# Altium schematic sheet PowerSupply.SchDoc(PowerSupply)
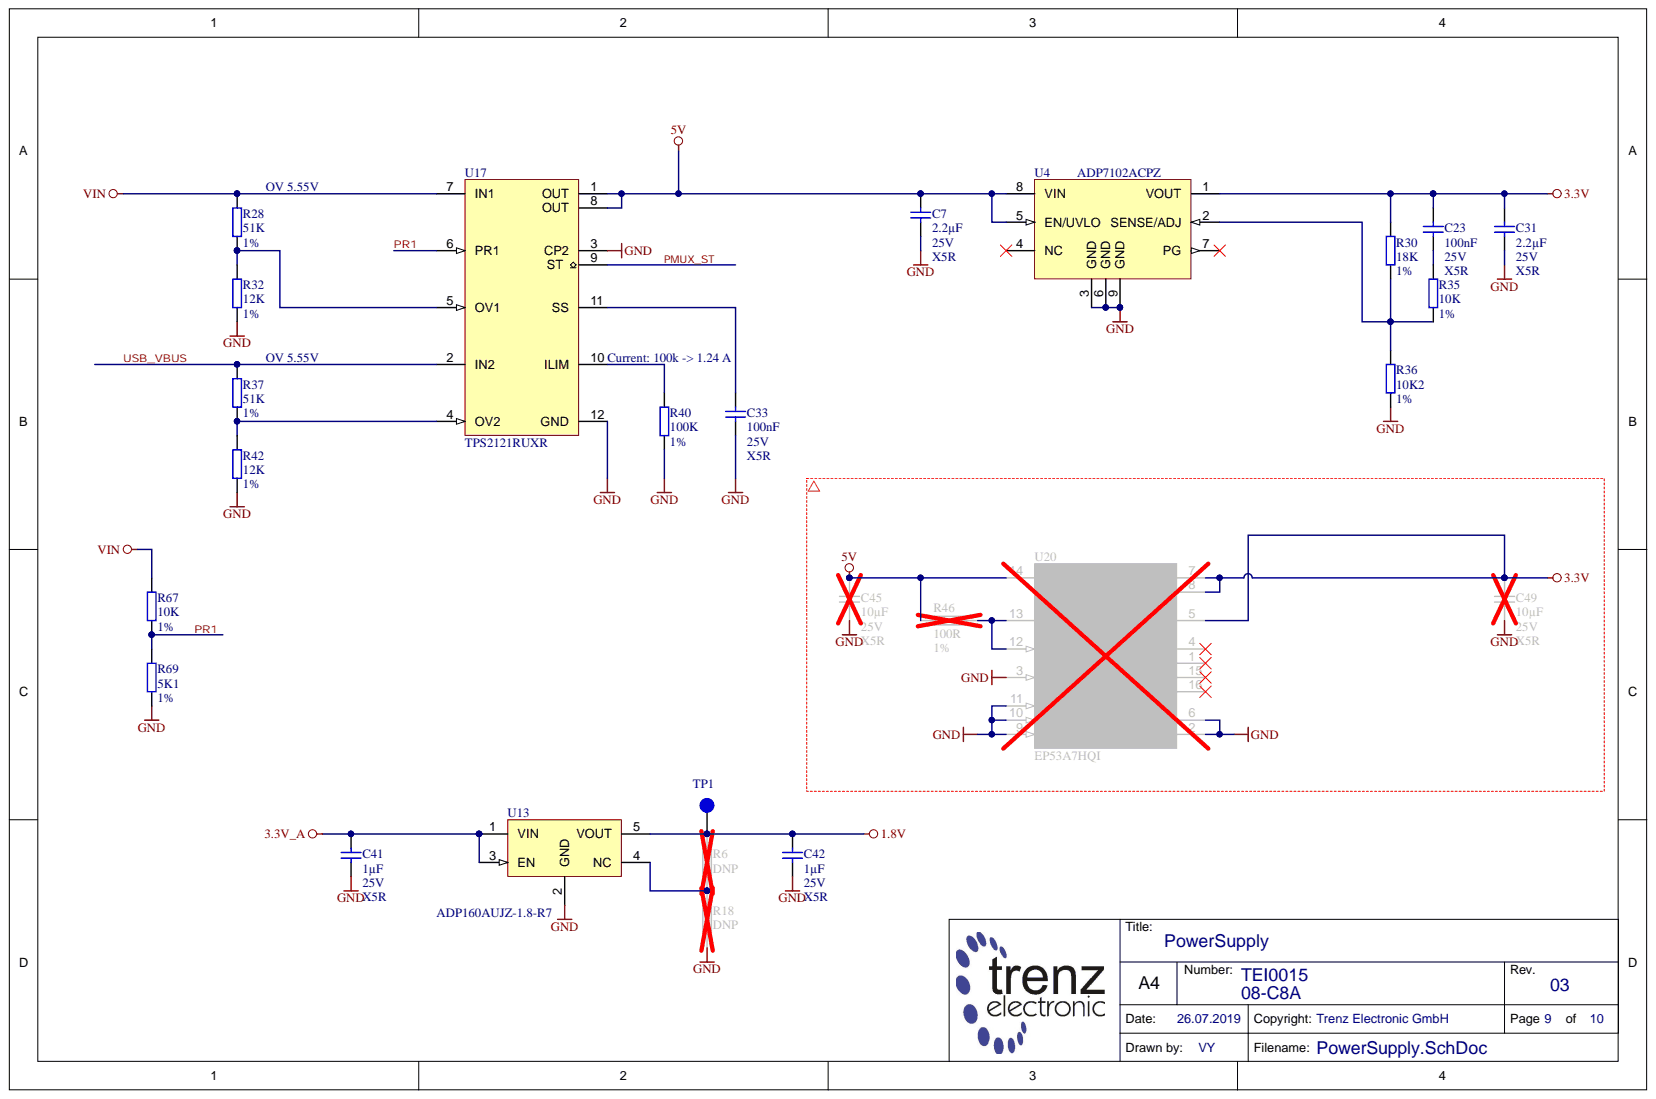
[diagram p0: PowerSupply.SchDoc]

## Parts
C7  2.2µF  Technology: X5R  Voltage: 25V  [CAPC1608X90N]
C23  100nF  Voltage: 25V  [CAPC1005X55N]
C31  2.2µF  Technology: X5R  Voltage: 25V  [CAPC1608X90N]
C33  100nF  Technology: X5R  Voltage: 25V  [CAPC1005X55N]
C41  1µF  Technology: X5R  Voltage: 25V  [CAPC1608X90N]
C42  1µF  Technology: X5R  Voltage: 25V  [CAPC1608X90N]
C45  10µF  Technology: X5R  Voltage: 25V  [CAPC2012X135N]
C49  10µF  Technology: X5R  Voltage: 25V  [CAPC2012X135N]
R6  DNP  [RESC1005X40N]  (no BOM)
R18  DNP  [RESC1005X40N]  (no BOM)
R28  51K  Tolerance: 1%  [RESC1005X40N]
R30  18K  Tolerance: 1%  [RESC1005X40N]
R32  12K  Tolerance: 1%  [RESC1005X40N]
R35  10K  Tolerance: 1%  [RESC1005X40N]
R36  10K2  Tolerance: 1%  [RESC1005X40N]
R37  51K  Tolerance: 1%  [RESC1005X40N]
R40  100K  Tolerance: 1%  [RESC1005X40N]
R42  12K  Tolerance: 1%  [RESC1005X40N]
R46  100R  Tolerance: 1%  [RESC1005X40N]
R67  10K  Tolerance: 1%  [RESC1005X40N]
R69  5K1  Tolerance: 1%  [RESC1005X40N]
TP1  Testpoint 0.8mm  [TP_0.8mm]  (value not drawn)
U4  ADP7102ACPZ  [SON50P300X300X80-9N]
U13  ADP160AUJZ-1.8-R7  [SOT95P280X100-5N]
U17  TPS2121RUXR  [QFNP50P200X250X100-12N]
U20  EP53A7HQI  [EP53F8QI]

## Nets
1.8V: C42.1 R6.2 TP1.1 U13.5(VOUT)
3.3V: C23.1 C31.1 C49.1 R30.1 U4.1(VOUT) U20.5(VSENSE) U20.7(VOUT) U20.8(VOUT)
3.3V_A: C41.1 U13.1(VIN) U13.3(EN)
5V: C7.1 C45.1 R46.1 U4.5(EN/UVLO) U4.8(VIN) U17.1(OUT) U17.8(OUT) U20.14(PVIN)
GND: C7.2 C31.2 C33.2 C41.2 C42.2 C45.2 C49.2 R18.1 R32.2 R36.2 R40.2 R42.2 R69.2 U4.3(GND) U4.6(GND) U4.9(GND) U13.2(GND) U17.3(CP2) U17.12(GND) U20.2(PGND) U20.3(LLM) U20.6(AGND) U20.9(VS2) U20.10(VS1) U20.11(VS0)
VIN: R28.1 R67.1 U17.7(IN1)
PMUX_ST: U17.9(ST)
PR1: R67.2 R69.1 U17.6(PR1)
USB_VBUS: R37.1 U17.2(IN2)
NetC23_2: C23.2 R35.1
NetC33_1: C33.1 U17.11(SS)
NetR6_1: R6.1 R18.2 U13.4(NC)
NetR28_2: R28.2 R32.1 U17.5(OV1)
NetR30_2: R30.2 R35.2 R36.1 U4.2(SENSE/ADJ)
NetR37_2: R37.2 R42.1 U17.4(OV2)
NetR40_1: R40.1 U17.10(ILIM)
NetR46_2: R46.2 U20.12(ENABLE) U20.13(AVIN)

Not connected: U4 4(NC) 7(PG); U20 1(NC(SW)) 4(NC) 15(NC(SW)) 16(NC(SW))

## Library data of the parts
C7 C31 = 27910: KON 2.2uF 25V X5R ±10% SMD BF 1608 (0603); Database Table Name: C_1608_0603; Mixed: SMT; Temperature-Range: -55/+85; Value: 2.2u; AN: 27910; Case: 1608 (0603); Component Kind: Standard; Library Name: TE.DbLib; Tolerance: ±10%
C23 C33 = 22472: KON 100nF 25V X5R ±10% SMD BF 1005 (0402); Database Table Name: C_1005_0402; Mixed: SMT; Preferred: Yes; Temperature-Range: -55/+85; Value: 100n; AN: 22472; Case: 1005 (0402); Component Kind: Standard; Library Name: TE.DbLib
  C23: Technology: X5R
C41 C42 = 26837: KON 1µF 25V X5R ±10% SMD BF 1608 (0603); Database Table Name: C_1608_0603; Mixed: SMT; Preferred: Yes; Temperature-Range: -55/+85; Value: 1u; AN: 26837; Case: 1608 (0603); Component Kind: Standard; Library Name: TE.DbLib; Tolerance: 10%
C45 C49 = 25567: KON 10µF 25V X5R 10% SMD BF 2012 (0805); Database Table Name: C_2012_0805; Mixed: SMT; Temperature-Range: -55/+85; Value: 10u; AN: 25567; Case: 2012 (0805); Component Kind: Standard; Library Name: TE.DbLib; Tolerance: 10%
R6 R18 = 29416: WID SMD BF 1005 (0402) DNP; Database Table Name: R_1005_0402; AN: 29416; Case: 1005 (0402); Library Name: TE.DbLib; Value: DNP
R28 R37 = 24466: WID SMD 51K 1% 0,063W; Database Table Name: R_1005_0402; Mixed: SMT; AN: 24466; Case: 1005 (0402); Component Kind: Standard; Library Name: TE.DbLib; Technology: TK100; Value: 51K
R30 = 24875: WID SMD 18K 1% 0,063W  BF 1005 (0402); Database Table Name: R_1005_0402; Mixed: SMT; Preferred: Yes; AN: 24875; Case: 1005 (0402); Component Kind: Standard; Library Name: TE.DbLib; Technology: TK100; Value: 18K
R32 R42 = 22598: WID SMD 12K 1% 0,063W  BF 1005 (0402); Database Table Name: R_1005_0402; Mixed: SMT; Preferred: Yes; AN: 22598; Case: 1005 (0402); Component Kind: Standard; Library Name: TE.DbLib; Technology: TK100; Value: 12K
R35 R67 = 22750: WID SMD 10K 1% 0,063W BF 1005 (0402); Database Table Name: R_1005_0402; H-ART: RC0402FR-0710KL; Mixed: SMT; Preferred: Yes; AN: 22750; Case: 1005 (0402); Component Kind: Standard; Library Name: TE.DbLib; Technology: TK100; Value: 10K
R36 = 28019: WID SMD 10K2 1% 0,063W BF 1005 (0402); Database Table Name: R_1005_0402; H-ART: MCWR04X1022FTL; Mixed: SMT; Value: 10.2K; AN: 28019; Case: 1005 (0402); Component Kind: Standard; Library Name: TE.DbLib; Technology: TK100
R40 = 22558: WID SMD 100K 1% 0,063W  BF 1005 (0402); Database Table Name: R_1005_0402; H-ART: RC0402FR-13100KL; Mixed: SMT; Preferred: Yes; AN: 22558; Case: 1005 (0402); Component Kind: Standard; Library Name: TE.DbLib; Technology: TK100; Value: 100K
R46 = 22507: WID SMD 100R 1% 0,063W; Database Table Name: R_1005_0402; Mixed: SMT; Preferred: Yes; Value: 100; AN: 22507; Case: 1005 (0402); Component Kind: Standard; Library Name: TE.DbLib; Technology: TK100
R69 = 23125: WID SMD 5K1 1% 0,063W BF 1005 (0402); Database Table Name: R_1005_0402; Mixed: SMT; Value: 5.1K; AN: 23125; Case: 1005 (0402); Component Kind: Standard; Library Name: TE.DbLib; Technology: TK100
TP1 = 26289: Database Table Name: PCB; Mixed: MECH; AN: 26289; Component Kind: Standard; Description: Testpoint 0.8mm; Library Name: TE.DbLib
U4 = 24611: ADP7102ACPZ-R7; Database Table Name: LREG; Mixed: SMT; Trenz-Stock: Yes; AN: 24611; Case: DFN8; Component Kind: Standard; H-ART: ADP7102ACPZ-R7; Library Name: TE.DbLib; HelpURL: B:\AD\adlib\datasheet\ADP7102
U13 = 28939: Database Table Name: LREG; Mixed: SMT; Voltage: 1.8V; AN: 28939; Case: SOT23-5; Component Kind: Standard; Description: ADP160AUJZ-1.8-R7; H-ART: ADP160AUJZ-1.8-R7; Library Name: TE.DbLib; HelpURL: b:\AD\adlib\datasheet\ADP16x\
U17 = 29703: Database Table Name: SUPERVISOR; Mixed: SMT; Temperature-Range: -40/+125 (Tj); AN: 29703; Case: QFN12; Component Kind: Standard; Description: TPS2121RUXR; H-ART: TPS2121RUXR; Library Name: TE.DbLib; Storage-Temperature: -65/+150(Abs); HelpURL: b:\AD\adlib\datasheet\TPS212x\
U20 = 27996: Database Table Name: DCDC; Mixed: SMT; Preferred: Yes; AN: 27996; Case: QFN16; Component Kind: Standard; Description: EP53A7HQI; H-ART: EP53A7HQI; Library Name: TE.DbLib; Trenz-Stock: Yes; HelpURL: B:\AD\adlib\datasheet\EP53A7HQI
